# Altium schematic sheet Voltage_Regulator_5V.SchDoc
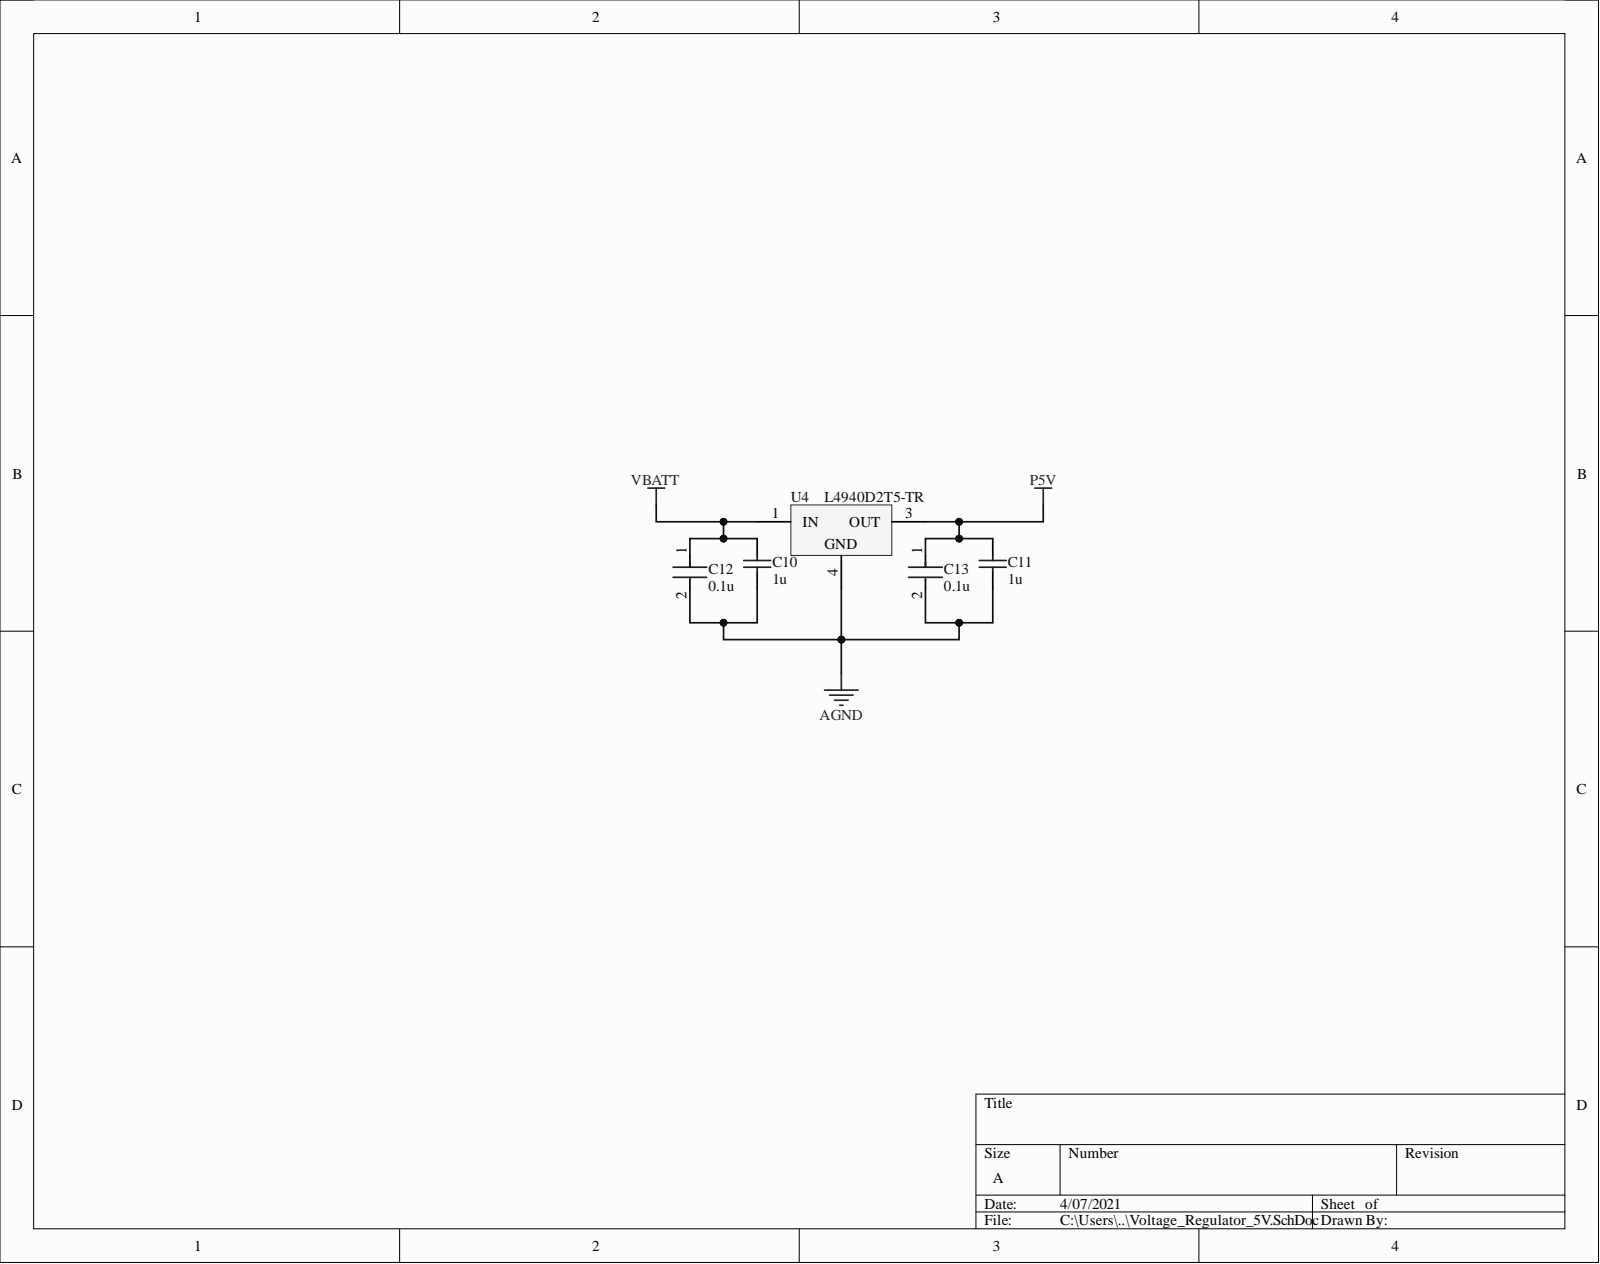
[diagram p0: Voltage_Regulator_5V.SchDoc]

## Parts
C10  1u  [CAPC3216X110X50LL20T25]
C11  1u  [CAPC3216X110X50LL20T25]
C12  0.1u  [FP-C0402-BB-MFG]
C13  0.1u  [FP-C0402-BB-MFG]
U4  L4940D2T5-TR  [TO-263_M]

## Nets
AGND: C10.1 C11.1 C12.2 C13.2 U4.4
P5V: C11.2 C13.1 U4.3
VBATT: C10.2 C12.1 U4.1

## Library data of the parts
C10 C11 = CMP-2000-06011-1: Chip Capacitor, 1 uF, +/- 10%, 50 V, -55 to 125 degC, 1206 (3216 Metric), RoHS, Tape and Reel; Brand: Kemet; Capacitance: 1uF; Capacitance - nF: 1000nF; Case Height: 1mm; Case Length: 3.2mm; Case Width: 1.6mm; Class: Class1; Dielectric: X7R; Temperature Coefficient / Code: +/-15%; Type: SMDMLCCX7R6.3-250VDCCommericalGrade; Voltage Rating: 50V; Case Code - in: 1206; Case Code - mm: 3216; Component Kind: Standard; Datasheet URL: http://www.kemet.com/docfinder?Partnumber=C1206C105K5RACTU; Datasheet Version: 02/2016; Dimensions: 1.6mmWx3.2mmLx1mmH; Factory Pack Quantity: 2500; Library Name: Altium Content Vault; Manufacturer: Kemet; Manufacturer URL: http://www.kemet.com/; Maximum Operating Temperature: +125C; Minimum Operating Temperature: -55C; Mounting Technology: SurfaceMount; Operating Temperature Range: -55Cto+125C; Package / Case: 1206(3216metric); Package Description: 2-Pin Surface Mount Device, Body 3.2 x 1.6 mm; Package Reference: 1206; Packaging: Reel; Product: GeneralTypeMLCCs; Product Category: MultilayerCeramicCapacitorsMLCC-SMD/SMT; RoHS: Details; Series: C1206C; Termination Style: SMD/SMT; Tolerance: 10%; Voltage Rating DC: 50V
C12 C13 = CMP-2008-02046-2: CAP CER 0.1UF 10V X5R 0402; Manufacturer: Kemet; Manufacturer Part Number: C0402C104K8PACTU; Capacitance: 0.10 μF; Material: Ceramic; Temperature Coefficient: 15%; Tolerance: 0.1; Value: 0.10 μF; Automotive: No; Category: Cap; Component Kind: Standard; ComponentLink2Description: Datasheet; ComponentLink2URL: https://content.kemet.com/datasheets/KEM_C1002_X7R_SMD.pdf; Device Class L1: Passive Components; Device Class L2: Capacitors; Device Class L3: Ceramic Capacitors; Digikey Description: CAP CER 0.1UF 10V X5R 0402; Height: 0.55mm; Ipc Land Pattern Name: CAPC10050X50; Lead Free: Yes; Library Name: Altium Content Vault; Mouser Description: Capacitor 100 nF 10 VDC 0402; Octopart: https://octopart.com/c0402c104k8pactu-kemet-140039; Package: C0402-BB; RoHS: TRUE; Temperature: -55°C to +125°C; Temperature Characteristic: X5R; Temperature Range High: +125°C; Temperature Range Low: -55°C; Voltage Rating: 10 V
U4 = CMP-0244-00196-1: 1.5A Very Low Drop Regulator, 3-Pin D2PAK, Tape and Reel; Component Kind: Standard; ComponentLink1Description: Manufacturer URL; ComponentLink1URL: http://www.st.com; ComponentLink2Description: Datasheet; ComponentLink2URL: http://www.st.com/internet/com/TECHNICAL_RESOURCES/TECHNICAL_LITERATURE/DATASHEET/CD00000442.pdf; DatasheetVersion: 16/12/2009; Library Name: Altium Content Vault; PackageDescription: 3-Pin Transistor Outline Package 2.54 mm Pitch, 15.4 mm Lead Span (D2PAK); PackageReference: TO-263; PackageVersion: 2011
